# Altium schematic sheet misc.SchDoc(misc)
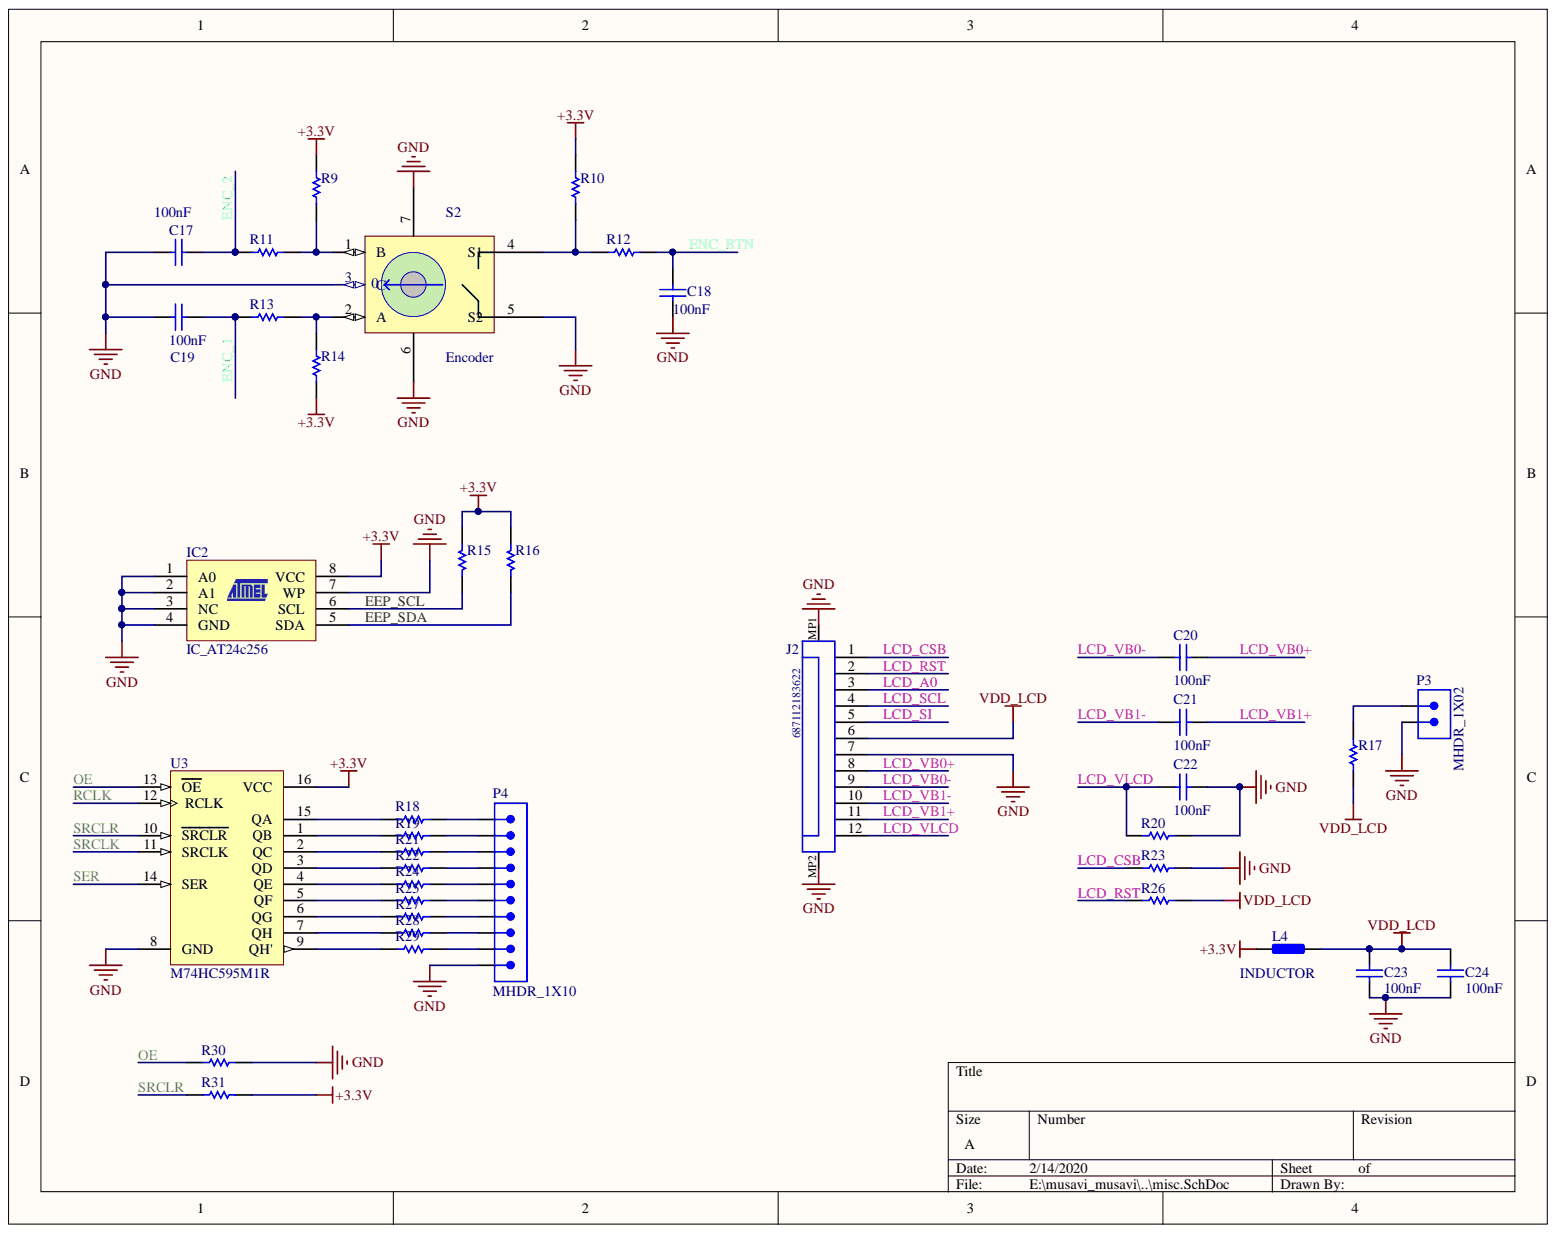
[diagram p0: misc.SchDoc]

## Parts
C17  100nF  [CAPC3216M (1206)]
C18  100nF  [CAPC3216M (1206)]
C19  100nF  [CAPC3216M (1206)]
C20  100nF  [CAPC3216M (1206)]
C21  100nF  [CAPC3216M (1206)]
C22  100nF  [CAPC3216M (1206)]
C23  100nF  [CAPC3216M (1206)]
C24  100nF  [CAPC3216M (1206)]
IC2  IC_AT24c256  [CDIP8]
J2  687112183622  [PCBComponent_1]
L4  INDUCTOR  [INDC4532]
P3  MHDR_1X02  [MHDR1X02-254P]
P4  MHDR_1X10  [MHDR1X10-254P - E]
R9  1K  [RESC3216X60M (1206)]  (value not drawn)
R10  1K  [RESC3216X60M (1206)]  (value not drawn)
R11  1K  [RESC3216X60M (1206)]  (value not drawn)
R12  1K  [RESC3216X60M (1206)]  (value not drawn)
R13  1K  [RESC3216X60M (1206)]  (value not drawn)
R14  1K  [RESC3216X60M (1206)]  (value not drawn)
R15  1K  [RESC3216X60M (1206)]  (value not drawn)
R16  1K  [RESC3216X60M (1206)]  (value not drawn)
R17  1K  [RESC3216X60M (1206)]  (value not drawn)
R18  1K  [RESC3216X60M (1206)]  (value not drawn)
R19  1K  [RESC3216X60M (1206)]  (value not drawn)
R20  1K  [RESC3216X60M (1206)]  (value not drawn)
R21  1K  [RESC3216X60M (1206)]  (value not drawn)
R22  1K  [RESC3216X60M (1206)]  (value not drawn)
R23  1K  [RESC3216X60M (1206)]  (value not drawn)
R24  1K  [RESC3216X60M (1206)]  (value not drawn)
R25  1K  [RESC3216X60M (1206)]  (value not drawn)
R26  1K  [RESC3216X60M (1206)]  (value not drawn)
R27  1K  [RESC3216X60M (1206)]  (value not drawn)
R28  1K  [RESC3216X60M (1206)]  (value not drawn)
R29  1K  [RESC3216X60M (1206)]  (value not drawn)
R30  1K  [RESC3216X60M (1206)]  (value not drawn)
R31  1K  [RESC3216X60M (1206)]  (value not drawn)
S2  Encoder  [Encoder]
U3  M74HC595M1R  [SO16_L]

## Nets
+3.3V: IC2.8(VCC) L4.1 R9.2 R10.2 R14.1 R15.1 R16.1 R31.1 U3.16(VCC)
GND: C17.1 C18.2 C19.1 C22.2 C23.2 C24.2 IC2.1(A0) IC2.2(A1) IC2.3(NC) IC2.4(GND) IC2.7(WP) J2.7 J2.MP1 J2.MP2 P3.2 P4.10 R20.1 R23.1 R30.1 S2.3 S2.5 S2.6 S2.7 U3.8(GND)
VDD_LCD: C23.1 C24.1 J2.6 L4.2 R17.2 R26.1
EEP_SCL: IC2.6(SCL) R15.2
EEP_SDA: IC2.5(SDA) R16.2
ENC_1: C19.2 R13.2
ENC_2: C17.2 R11.2
ENC_BTN: C18.1 R12.1
LCD_A0: J2.3
LCD_CSB: J2.1 R23.2
LCD_RST: J2.2 R26.2
LCD_SCL: J2.4
LCD_SI: J2.5
LCD_VB0+: C20.1 J2.8
LCD_VB0-: C20.2 J2.9
LCD_VB1+: C21.1 J2.11
LCD_VB1-: C21.2 J2.10
LCD_VLCD: C22.1 J2.12 R20.2
OE: R30.2 U3.13(OE)
RCLK: U3.12(RCLK)
SER: U3.14(SER)
SRCLK: U3.11(SRCLK)
SRCLR: R31.2 U3.10(SRCLR)
NetP3_1: P3.1 R17.1
NetP4_1: P4.1 R18.1
NetP4_2: P4.2 R19.1
NetP4_3: P4.3 R21.1
NetP4_4: P4.4 R22.1
NetP4_5: P4.5 R24.1
NetP4_6: P4.6 R25.1
NetP4_7: P4.7 R27.1
NetP4_8: P4.8 R28.1
NetP4_9: P4.9 R29.1
NetR9_1: R9.1 R11.1 S2.1(B)
NetR10_1: R10.1 R12.2 S2.4(S1)
NetR13_1: R13.1 R14.2 S2.2(A)
NetR18_2: R18.2 U3.15(QA)
NetR19_2: R19.2 U3.1(QB)
NetR21_2: R21.2 U3.2(QC)
NetR22_2: R22.2 U3.3(QD)
NetR24_2: R24.2 U3.4(QE)
NetR25_2: R25.2 U3.5(QF)
NetR27_2: R27.2 U3.6(QG)
NetR28_2: R28.2 U3.7(QH)
NetR29_2: R29.2 U3.9(QH')

## Library data of the parts
C17-C24 = CAP_Bipolar: Bipolar Capacitor; Voltage: 100V; Designer: Eng. Saeid Jorablo; Company: SJ Libraries; Value: 100nF; Status: Unreleased; Mounting Technology: Surface Mount\Through-Hole; Library Name: SJ Passive Components.IntLib; HelpURL: http://s3.picofile.com/file/7443264187/Coming_Soon_logo.gif; ComponentLink2Description: Reference; ComponentLink1Description: Datasheet; Component Kind: Standard; Publisher: SJ Unlimited
IC2 = IC_AT24c256: EEPROM Memory; Revision 2: 7/1/2012: Add Suppliers; ESD Sensetive: True/False; Designer: Eng. Saeid Jorablo; Company: SJ Libraries; Update: 6/5/2012; Supplier Part Number 3: AT24C256-10PI-2.7-ND; Supplier Part Number 2: AT24C256N-10SC-ND; Supplier Part Number 1: AT24C256-10TI-2.7-ND; Supplier 3: Digi-Key; Supplier 2: Digi-Key; Supplier 1: Digi-Key; Status: Unrelease; RoHS compliant: True/False; PinCount: 8; Operating Temperature: -55 ~ +125 (C); Mounting Technology: Surface Mount; Library Name: SJ Altium Library.IntLib; HelpURL: http://s3.picofile.com/file/7443264187/Coming_Soon_logo.gif; ComponentLink2Description: Reference; ComponentLink1URL: www.datasheet4u.com; ComponentLink1Description: Datasheet; Component Kind: Standard; Category: Integrated Circuit
J2 = 687112183622: SMT ZIF Connectors Low Profile WR-FPC, Horizontal, Top Contact, pitch 0.6 mm, 12 pins; Working Voltage: 50 VAC; Withstanding Voltage: 250 VAC; Rated Current: 0.4 A; Pitch: 0.6 mm; Pin Number: 12; Contact Resistance: 30 mOhm; Published Date: August 2018; Part Number: 687112183622; Packaging: Tape and Reel; Orientation: Horizontal; Operating Temperature: -25 to 85 degC; Mounting Technology: SMT; Library Name: Altium_FPC_CONNECTORS (rev18c).IntLib; Contact Design: Top Contact; ComponentLink2URL: https://katalog.we-online.de/em/datasheet/687112183622.pdf; ComponentLink2Description: Datasheet; ComponentLink1URL: http://www.we-online.de; ComponentLink1Description: Manufacturer; Component Kind: Standard; Publisher: Wurth Elektronik
L4 = INDUCTOR: Value: 10mH; Designer: Eng. Saeid Jorablo; Company: SJ Libraries; Code_IEC: 1005; Status: Unreleased; Published: 8-Jun-2000; PackageReference: 0402-A; PackageDescription: Chip Inductor; Mounting Technology: Surface Mount\Through-Hole; Library Name: SJ Passive Components.IntLib; LatestRevisionNote: IPC-7351 Footprint Added.; LatestRevisionDate: 29-May-2009; HelpURL: http://s3.picofile.com/file/7443264187/Coming_Soon_logo.gif; Description: Inductor; ComponentLink2Description: Reference; ComponentLink1Description: Datasheet; Component Kind: Standard; Code_JEITA: 0402; Publisher: SJ Unlimited
P3 = MHDR_1X02: Male Header 1X02; Library Name: SJ Sockets & Connectors.IntLib; Component Kind: Standard
P4 = MHDR_1X10: Male Header 1X10; Library Name: SJ Sockets & Connectors.IntLib; Component Kind: Standard
R9-R31 = RES: Resistor; Tolerance: 5%; Power: 1/8 W; Designer: Eng. Saeid Jorablo; Company: SJ Libraries; Value: 1K; Status: Unreleased; Simulation: Resistor; PackageDescription: SMD & TH Resistors; Mounting Technology: Surface Mount\Through-Hole; Library Name: SJ Passive Components.IntLib; HelpURL: http://s3.picofile.com/file/7443264187/Coming_Soon_logo.gif; ComponentLink2Description: Reference; ComponentLink1Description: Datasheet; Component Kind: Standard; Category: Resistors; Publisher: SJ Unlimited
U3 = M74HC595M1R: 8 Bit Shift Register with Output Latches (3 STATE); Published: 8-Jun-2000; PCB3D: SO16; PackageVersion: May-1998; PackageReference: SO16; PackageDescription: 16-Pin Small Outline Integrated Circuit 1.27 mm Pitch; Library Name: ST Logic Register.IntLib; LatestRevisionNote: IPC-7351 Footprint Added.; LatestRevisionDate: 13-Apr-2006; DatasheetVersion: Apr-1993; ComponentLink2URL: http://www.st.com/stonline/products/literature/ds/1989/m74hc595.pdf; ComponentLink2Description: Datasheet; ComponentLink1URL: http://www.st.com/; ComponentLink1Description: Manufacturer Link; Component Kind: Standard; Code_IPC: SOIC127P600-16; Publisher: Altium Limited
S2 = Encoder: Library Name: stm8.SchLib; Component Kind: Standard
